# Altium schematic sheet Sheet1.SchDoc
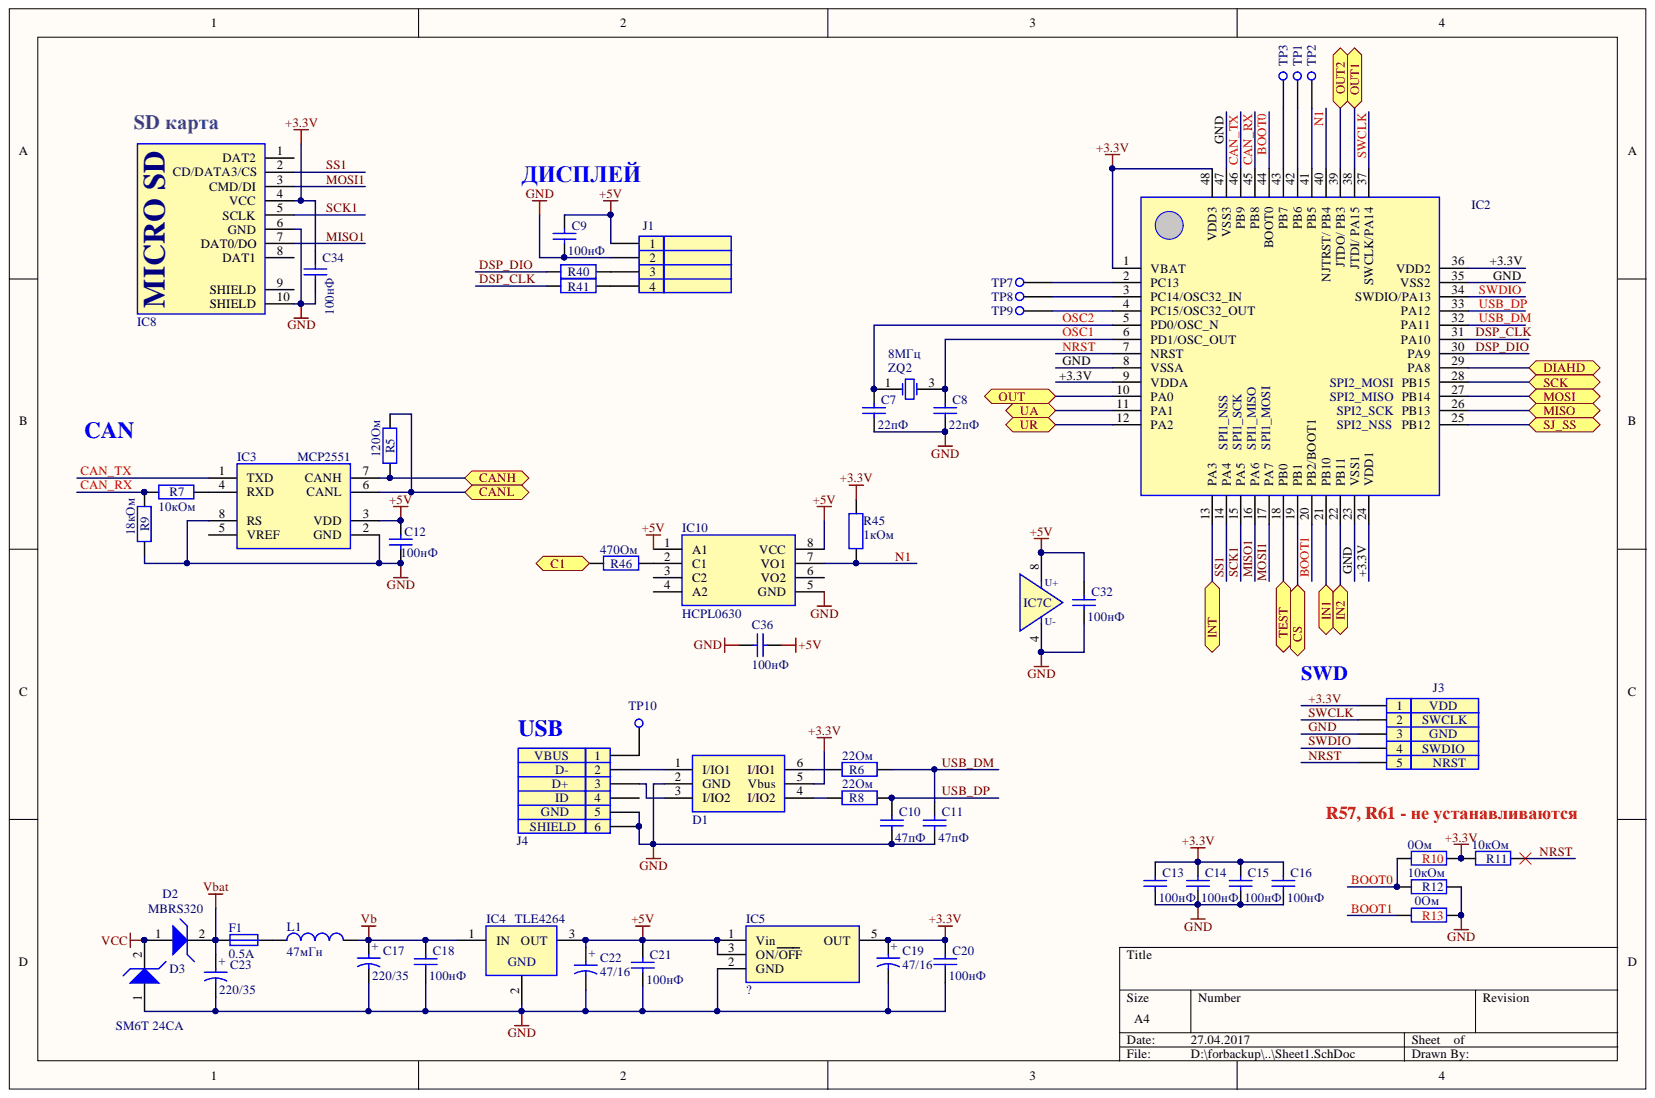
[diagram p0: Sheet1.SchDoc]

## Parts
C7  value 22пФ  [0603]  (hidden comment: Cap)
C8  value 22пФ  [0603]  (hidden comment: Cap)
C9  value 100нФ  [0603]  (hidden comment: Cap)
C10  value 47пФ  [0603]  (hidden comment: Cap)
C11  value 47пФ  [0603]  (hidden comment: Cap)
C12  value 100нФ  [0603]  (hidden comment: Cap)
C13  value 100нФ  [0603]  (hidden comment: Cap)
C14  value 100нФ  [0603]  (hidden comment: Cap)
C15  value 100нФ  [0603]  (hidden comment: Cap)
C16  value 100нФ  [0603]  (hidden comment: Cap)
C17  value 220/35  Order: 220/35  [SMD 8x10.5]  (hidden comment: Cap2)
C18  value 100нФ  [0603]  (hidden comment: Cap)
C19  value 47/16  Order: 47/16  [SMD 6.3x5.4]  (hidden comment: Cap2)
C20  value 100нФ  [0603]  (hidden comment: Cap)
C21  value 100нФ  [0603]  (hidden comment: Cap)
C22  value 47/16  Order: 47/16  [SMD 6.3x5.4]  (hidden comment: Cap2)
C23  value 220/35  Order: 220/35  [SMD 8x10.5]  (hidden comment: Cap2)
C32  value 100нФ  [0603]  (hidden comment: Cap)
C34  value 100нФ  [0603]  (hidden comment: Cap)
C36  value 100нФ  [0603]  (hidden comment: Cap)
D1  USBLC6-2  [SOT23-6]  (value not drawn)
D2  value MBRS320  Order: MBRS320  [DO-214AB]  (hidden comment: ZENER)
D3  value SM6T 24CA  Order: SM6T 24CA  [DO-214AA]  (hidden comment: ZENER)
F1  value 0.5A  Order: 0.5A  [1812Fuse]  (hidden comment: FUSE)
IC2  STM32F10x_LQFP48  [LQFP48-0.5]  (value not drawn)
IC3  MCP2551  [SO-8]
IC4  value TLE4264  [SOT223]  (hidden comment: SOT223)
IC5  LP2980  [SOT23-5]  (value not drawn)
IC7  LM258  [SO-8]  (value not drawn)  (part C)
IC8  MICRO_SD_SLOT  [MICRO SD CARD 2]  (value not drawn)
IC10  HCPL0630  [SO-8]
J1  s-4  [CWF-4R]  (value not drawn)
J3  s-5  [PLS-5]  (value not drawn)
J4  USB micro-BF  [USB micro B]  (value not drawn)
L1  value 47мГн  Order: 47мГн  [LQH32C]  (hidden comment: Inductor)
R5  value 120Ом  [0603]  (hidden comment: Res)
R6  value 22Ом  [0603]  (hidden comment: Res)
R7  value 10кОм  [0603]  (hidden comment: Res)
R8  value 22Ом  [0603]  (hidden comment: Res)
R9  value 18кОм  [0603]  (hidden comment: Res)
R10  value 0Ом  [0603]  (hidden comment: Res)
R11  value 10кОм  [0603]  (hidden comment: Res)
R12  value 10кОм  [0603]  (hidden comment: Res)
R13  value 0Ом  [0603]  (hidden comment: Res)
R40  Res  [0603]  (value not drawn)
R41  Res  [0603]  (value not drawn)
R45  value 1кОм  [0603]  (hidden comment: Res)
R46  value 470Ом  [0603]  (hidden comment: Res)
TP1  TP  [PAD_R1]
TP2  TP  [PAD_R1]
TP3  TP  [PAD_R1]
TP7  TP  [PAD_R1]
TP8  TP  [PAD_R1]
TP9  TP  [PAD_R1]
TP10  TP  [PAD_R1]
ZQ2  value 8МГц  Order: 8МГц  [KX-9A]  (hidden comment: QUARTZ_2)

## Ports
C1, CANH, CANL, CS, DIAHD, IN1, IN2, INT, MISO, MOSI, OUT, OUT1, OUT2, SCK, SJ_SS, TEST, UA, UR

## Nets
+5V: C9.2 C12.2 C21.2 C22.1 C32.2 C36.1 IC3.3(VDD) IC4.3 IC5.1(Vin) IC5.3(ON/OFF) IC7.8 IC10.1(A1) IC10.8(VCC) J1.1
VB: C17.1 C18.2 IC4.1 L1.2
VBAT: C23.1 D2.2 F1.1
VCC: D2.1 D3.2
+3.3V: C13.2 C14.2 C15.2 C16.2 C19.1 C20.2 C34.2 D1.5(Vbus) IC2.1(VBAT) IC2.9(VDDA) IC2.24(VDD1) IC2.36(VDD2) IC2.48(VDD3) IC5.5(OUT) IC8.4(VCC) J3.1 R10.2 R11.1 R45.1
BOOT0: IC2.44(BOOT0) R10.1 R12.1
BOOT1: IC2.20(PB2/BOOT1) R13.1
C1: R46.2 ; port C1
CAN_RX: IC2.45(PB8) R7.1 R9.2
CAN_TX: IC2.46(PB9) IC3.1(TXD)
CANH: IC3.7(CANH) R5.1 ; port CANH
CANL: IC3.6(CANL) R5.2 ; port CANL
CS: IC2.19(PB1) ; port CS
DIAHD: IC2.29(PA8) ; port DIAHD
DSP_CLK: IC2.31(PA10) R41.2
DSP_DIO: IC2.30(PA9) R40.2
GND: C7.1 C8.1 C9.1 C10.1 C11.1 C12.1 C13.1 C14.1 C15.1 C16.1 C17.2 C18.1 C19.2 C20.1 C21.1 C22.2 C23.2 C32.1 C34.1 C36.2 D1.2(GND) D3.1 IC2.8(VSSA) IC2.23(VSS1) IC2.35(VSS2) IC2.47(VSS3) IC3.2(GND) IC3.8(RS) IC4.2 IC5.2(GND) IC7.4 IC8.6(GND) IC8.10(SHIELD) IC10.5(GND) J1.2 J3.3 J4.5 J4.6 R9.1 R12.2 R13.2
IN1: IC2.21(PB10) ; port IN1
IN2: IC2.22(PB11) ; port IN2
INT: IC2.13(PA3) ; port INT
MISO: IC2.26(PB13) ; port MISO
MISO1: IC2.16(PA6) IC8.7(DAT0/DO)
MOSI: IC2.27(PB14) ; port MOSI
MOSI1: IC2.17(PA7) IC8.3(CMD/DI)
N1: IC2.40(NJTRST/_PB4) IC10.7(VO1) R45.2
NRST: IC2.7(NRST) J3.5 R11.2
OSC1: C8.2 IC2.6(PD1/OSC_OUT) ZQ2.3
OSC2: C7.2 IC2.5(PD0/OSC_N) ZQ2.1
OUT: IC2.10(PA0) ; port OUT
OUT1: IC2.38(JTDI/_PA15) ; port OUT1
OUT2: IC2.39(JTDO/_PB3) ; port OUT2
SCK: IC2.28(PB15) ; port SCK
SCK1: IC2.15(PA5) IC8.5(SCLK)
SJ_SS: IC2.25(PB12) ; port SJ_SS
SS1: IC2.14(PA4) IC8.2(CD/DATA3/CS)
SWCLK: IC2.37(SWCLK/PA14) J3.2
SWDIO: IC2.34(SWDIO/PA13) J3.4
TEST: IC2.18(PB0) ; port TEST
UA: IC2.11(PA1) ; port UA
UR: IC2.12(PA2) ; port UR
USB_DM: C11.2 IC2.32(PA11) R6.1
USB_DP: C10.2 IC2.33(PA12) R8.1
NetD1_1: D1.1(I/IO1) J4.2
NetD1_3: D1.3(I/IO2) J4.3
NetD1_4: D1.4(I/IO2) R8.2
NetD1_6: D1.6(I/IO1) R6.2
NetF1_2: F1.2 L1.1
NetIC2_2: IC2.2(PC13) TP7.1
NetIC2_3: IC2.3(PC14/OSC32_IN) TP8.1
NetIC2_4: IC2.4(PC15/OSC32_OUT) TP9.1
NetIC2_41: IC2.41(PB5) TP2.1
NetIC2_42: IC2.42(PB6) TP1.1
NetIC2_43: IC2.43(PB7) TP3.1
NetIC3_4: IC3.4(RXD) R7.2
NetIC10_2: IC10.2(C1) R46.1
NetJ1_3: J1.3 R40.1
NetJ1_4: J1.4 R41.1
NetJ4_1: J4.1 TP10.1

Not connected: IC3 5(VREF); IC8 1(DAT2) 8(DAT1) 9(SHIELD); IC10 3(C2) 4(A2) 6(VO2); J4 4

## Library data of the parts
C7 C8 = Cap: Capacitor; Function: Конденсатор неполярный; Group: Конденсаторы; Order: 22пФ 10% 50В 0805; Case: ?; Component Kind: Standard; Library Name: Конденсаторы.SchLib; Manufacturer: ?
C9 C12-C16 C18 C20 C21 C32 C36 = Cap: Capacitor; Function: Конденсатор неполярный; Group: Конденсаторы; Order: 100нФ 10% 50В 0805; Case: ?; Component Kind: Standard; Library Name: Конденсаторы.SchLib; Manufacturer: ?
C10 C11 = Cap: Capacitor; Function: Конденсатор неполярный; Group: Конденсаторы; Order: 47пФ 10% 50В 0805; Case: ?; Component Kind: Standard; Library Name: Конденсаторы.SchLib; Manufacturer: ?
C17 C19 C22 C23 = Cap2: Capacitor; Function: Конденсатор полярный; Group: Конденсаторы; Component Kind: Standard; Library Name: Конденсаторы.SchLib; Manufacturer: ?
  C17 C23: Case: SMD 8x10.5
  C19 C22: Case: ?
C34 = Cap: Capacitor; Component Kind: Standard; Order: ?; Library Name: Конденсаторы.SchLib
D1 = USBLC6-2: Function: Very low capacitance ESD protection; Group: Диоды; Case: ?; Component Kind: Standard; Library Name: Диоды.SchLib; Manufacturer: ?; Order: USBLC6-2; Value: USBLC6-2
D2 D3 = ZENER: Function: Zener диод; Group: Диоды; Component Kind: Standard; Library Name: Диоды.SchLib; Manufacturer: ?
  D2: Case: DO-214AB
  D3: Case: DO-214AA
F1 = FUSE: Resistor; Group: Разное; Case: 1812Fuse; Component Kind: Standard; Function: ?; Manufacturer: ?; Library Name: Резисторы.SchLib
IC2 = STM32F10x_LQFP48: Manufactirer: STM; Function: MCU; Group: Микросхемы; Case: LQFP48-0.5; Component Kind: Standard; Order: STM32F10x; Value: STM32F10x; Library Name: МК.SchLib
IC3 = MCP2551: Manufacturer: Microchip; Function: CAN передатчик; Order: Микросхемы; Order: MCP2551T-I/SN; Case: SO-8; Component Kind: Standard; Value: MCP2551; Library Name: Микросхемы интерфейс.SchLib
IC4 = TLE4264: Manufacturer: infineon; Function: Стабилизатор; Group: Микросхемы; Case: SOT223; Component Kind: Standard; Order: TLE4264; Library Name: Источники питания.SchLib
IC7 = LM258: Manufacturer: Texas Instruments; Function: ОУ; Group: Микросхемы; Order: LM258D; Case: SO-8; Component Kind: Standard; Library Name: Микросхемы ОУ.SchLib; Value: LM258
IC8 = MICRO_SD_SLOT: Function: Разъем для microSD карт; Group: Разъемы; Order: micro SD; Value: micro SD; Case: ?; Component Kind: Standard; Library Name: Разъемы.SchLib; Manufacturer: ?
IC10 = HCPL0630: Manufacturer: Fairchild Semiconductor; Function: Развязка с лог. выходом; Group: Микросхемы; Case: DIP-8; Component Kind: Standard; Order: HCPL0630; Value: HCPL0630; Library Name: Опто.SchLib
J1 = s-4: Group: Разъемы; Case: ?; Component Kind: Standard; Function: ?; Library Name: Разъемы.SchLib; Manufacturer: ?; Order: ?; Value: ?
J4 = USB micro-BF: Value: USB micro B; Case: ?; Component Kind: Standard; Library Name: Разъемы.SchLib
L1 = Inductor: Function: Индуктивность; Group: Индуктивности; Case: LQH32C; Component Kind: Standard; Description: Inductor; Library Name: Индуктивноcти.SchLib; Manufacturer: ?
R5 = Res: Resistor; Function: Резистор постоянный; Group: Резисторы; Order: 120Ом 5% 0805; Case: ?; Component Kind: Standard; Library Name: Резисторы.SchLib; Manufacturer: ?
R6 R8 = Res: Resistor; Function: Резистор постоянный; Group: Резисторы; Order: 22Ом 5% 0805; Case: ?; Component Kind: Standard; Library Name: Резисторы.SchLib; Manufacturer: ?
R7 R11 R12 = Res: Resistor; Function: Резистор постоянный; Group: Резисторы; Order: 10кОм 5% 0805; Case: ?; Component Kind: Standard; Library Name: Резисторы.SchLib; Manufacturer: ?
R9 = Res: Resistor; Function: Резистор постоянный; Group: Резисторы; Order: 18кОм 5% 0805; Case: ?; Component Kind: Standard; Library Name: Резисторы.SchLib; Manufacturer: ?
R10 R13 = Res: Resistor; Function: Резистор постоянный; Group: Резисторы; Order: 0Ом 5% 0805; Case: ?; Component Kind: Standard; Library Name: Резисторы.SchLib; Manufacturer: ?
R40 R41 = Res: Resistor; Function: Резистор постоянный; Group: Резисторы; Order: 100Ом 5% 0805; Value: 100Ом; Case: ?; Component Kind: Standard; Library Name: Резисторы.SchLib; Manufacturer: ?
R45 = Res: Resistor; Function: Резистор постоянный; Group: Резисторы; Order: 1кОм 5% 0805; Case: ?; Component Kind: Standard; Library Name: Резисторы.SchLib; Manufacturer: ?
R46 = Res: Resistor; Function: Резистор постоянный; Group: Резисторы; Order: 470Ом 5% 0805; Case: ?; Component Kind: Standard; Library Name: Резисторы.SchLib; Manufacturer: ?
TP1-TP3 TP7-TP10 = TP: Group: Не устанавливается; Case: ?; Component Kind: Standard; Function: ?; Manufactirer: ?; Order: tp; Value: tp; Library Name: Разъемы.SchLib
ZQ2 = KX-9A: Crystal Oscillator; Manufacturer: Кварцевый резонатор; Group: Резонаторы; Case: KX-9A; Component Kind: Standard; Function: Crystal Oscillator; Library Name: Резонаторы.SchLib
IC5 = LP2980: Component Kind: Standard; Order: ?; Value: ?; Library Name: Источники питания.SchLib
J3 = s-5: Component Kind: Standard; Order: PLS-5; Value: ?; Library Name: thermometr.SchLib
